# Altium schematic sheet Debug.SchDoc(Debug)
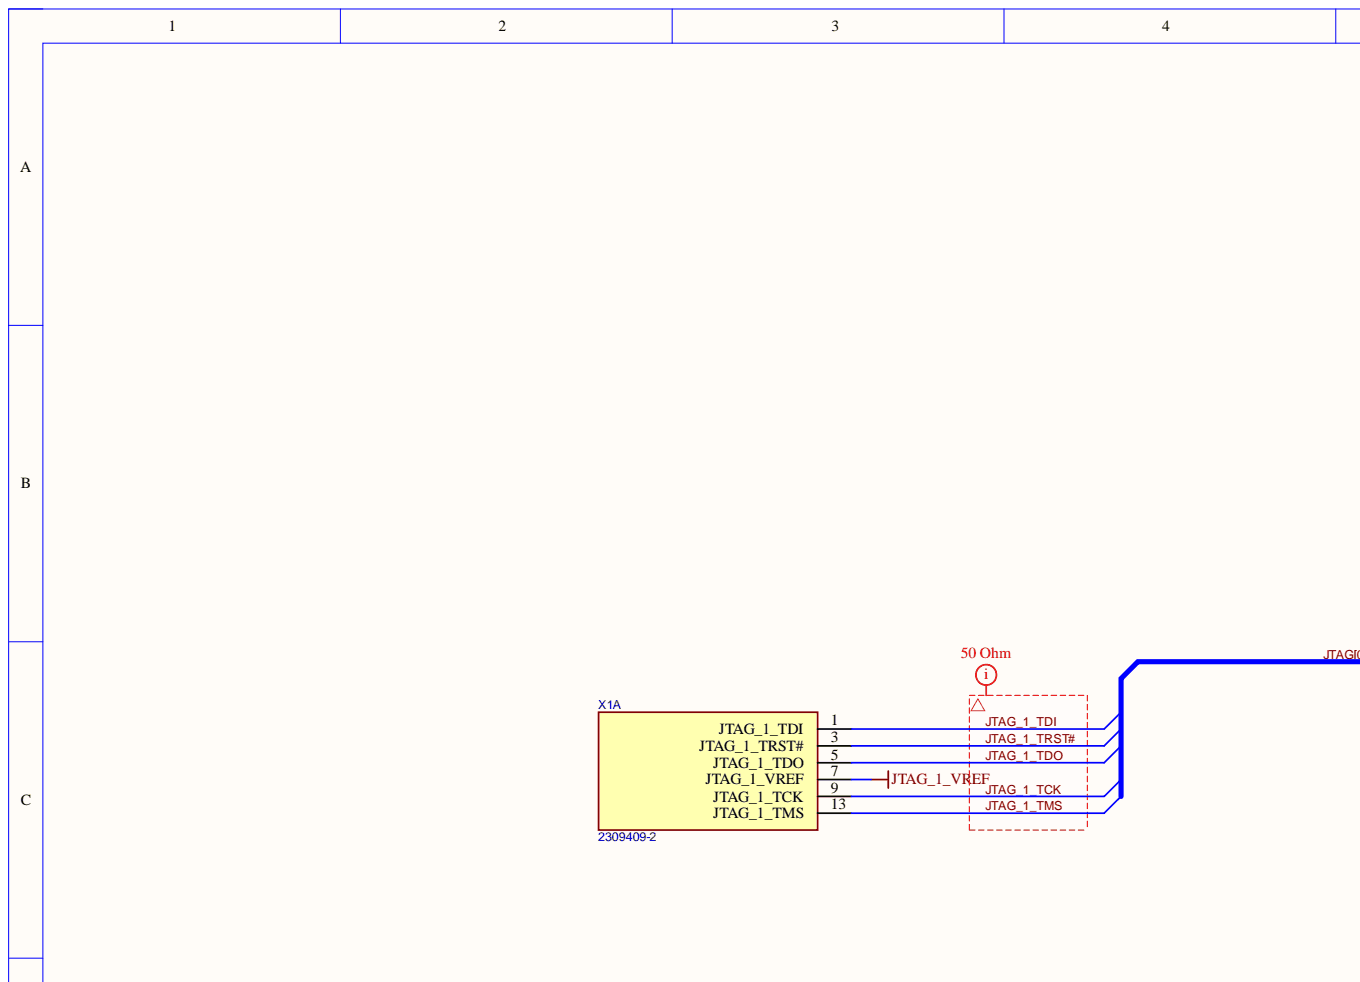
[diagram p0: Debug.SchDoc, top left]
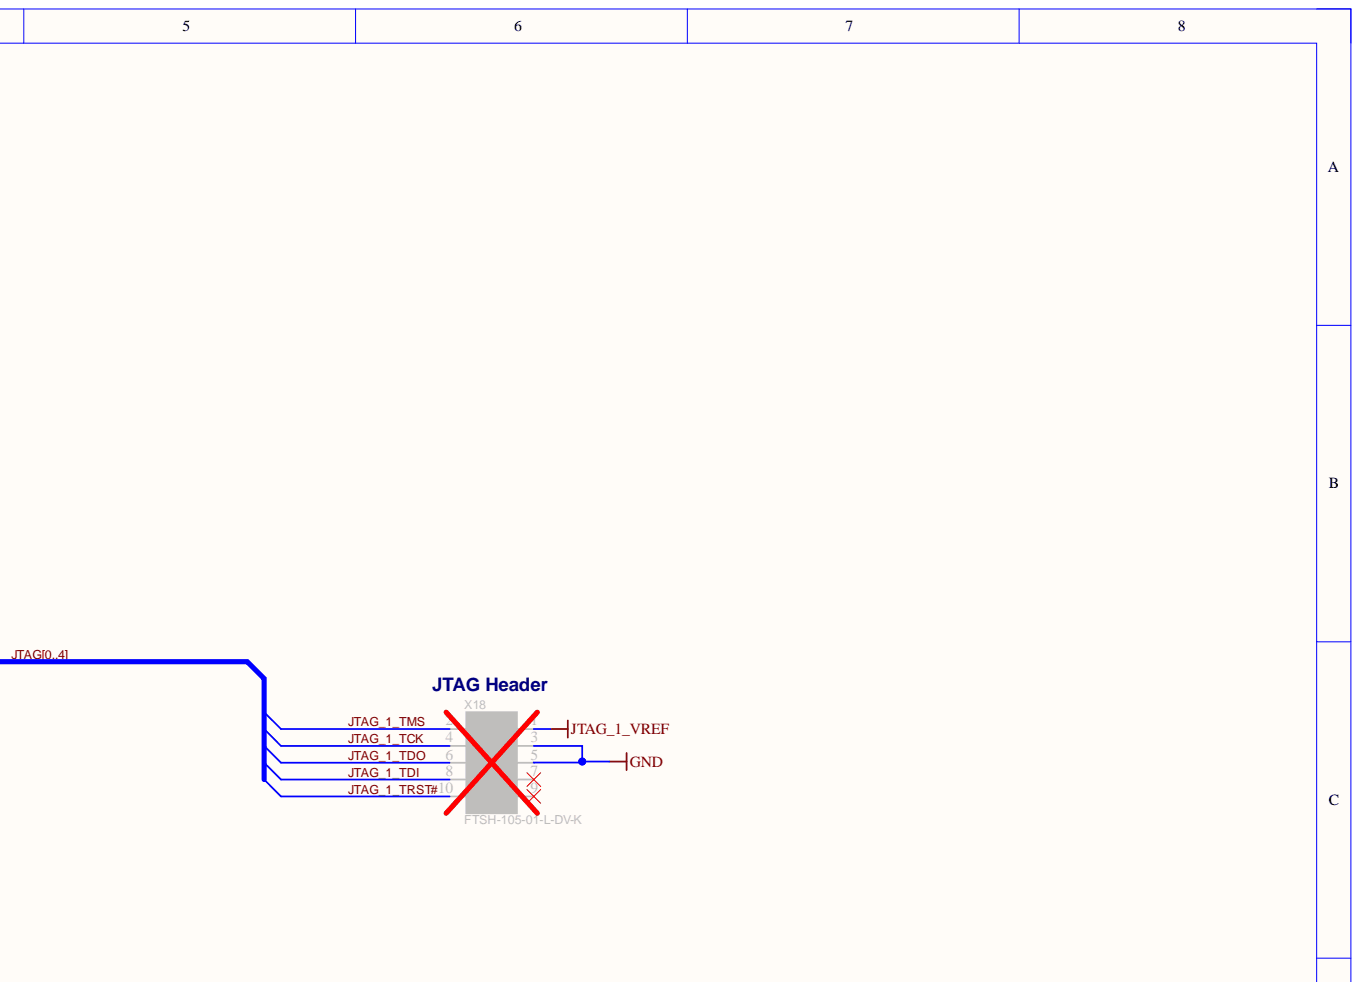
[diagram p1: Debug.SchDoc, top right]
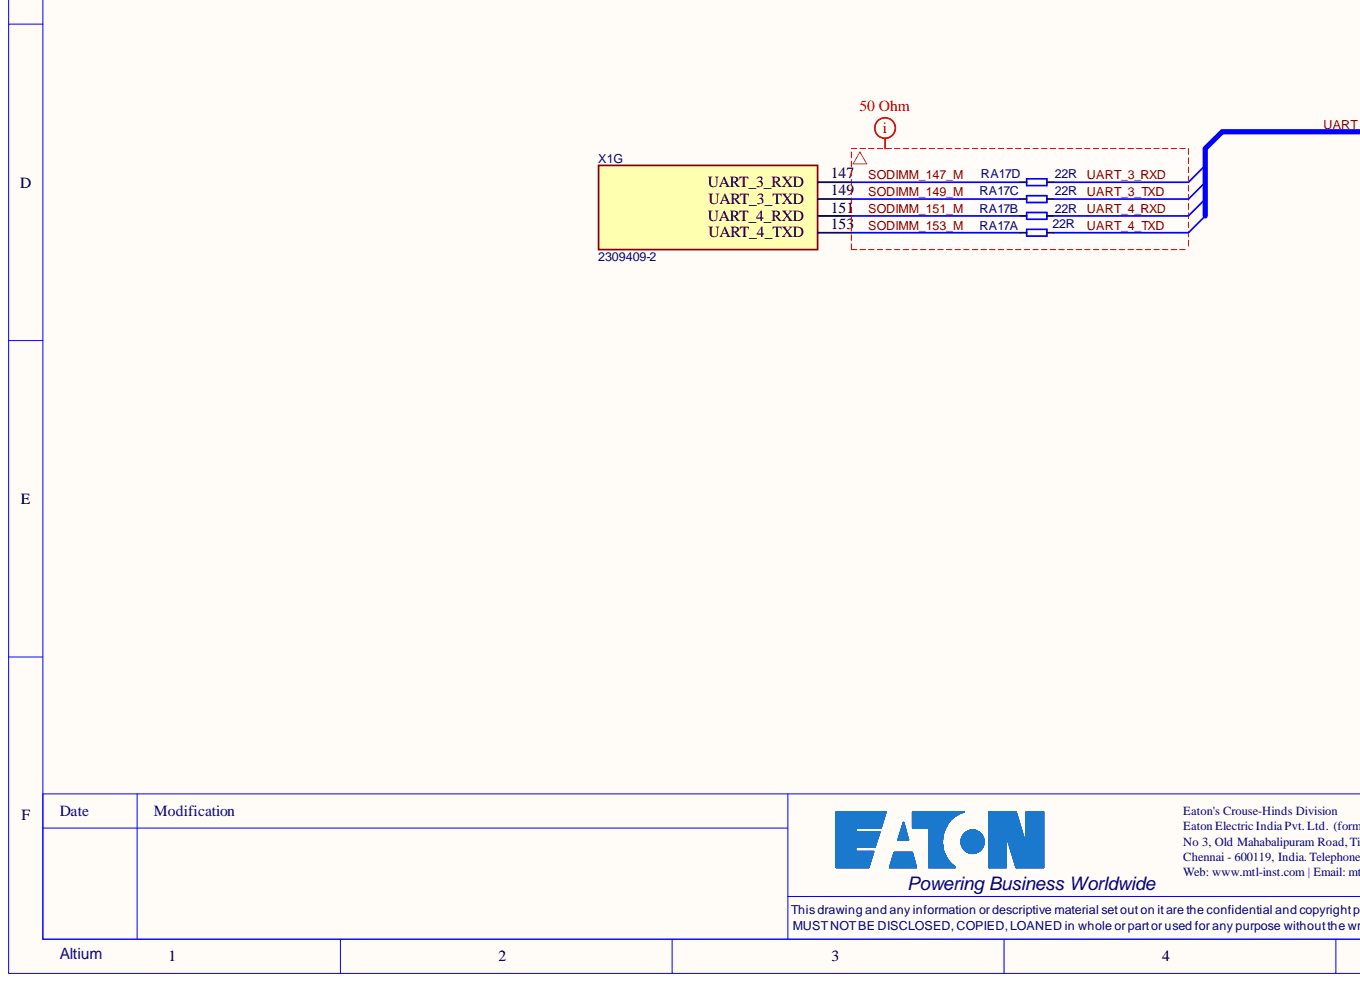
[diagram p2: Debug.SchDoc, bottom left]
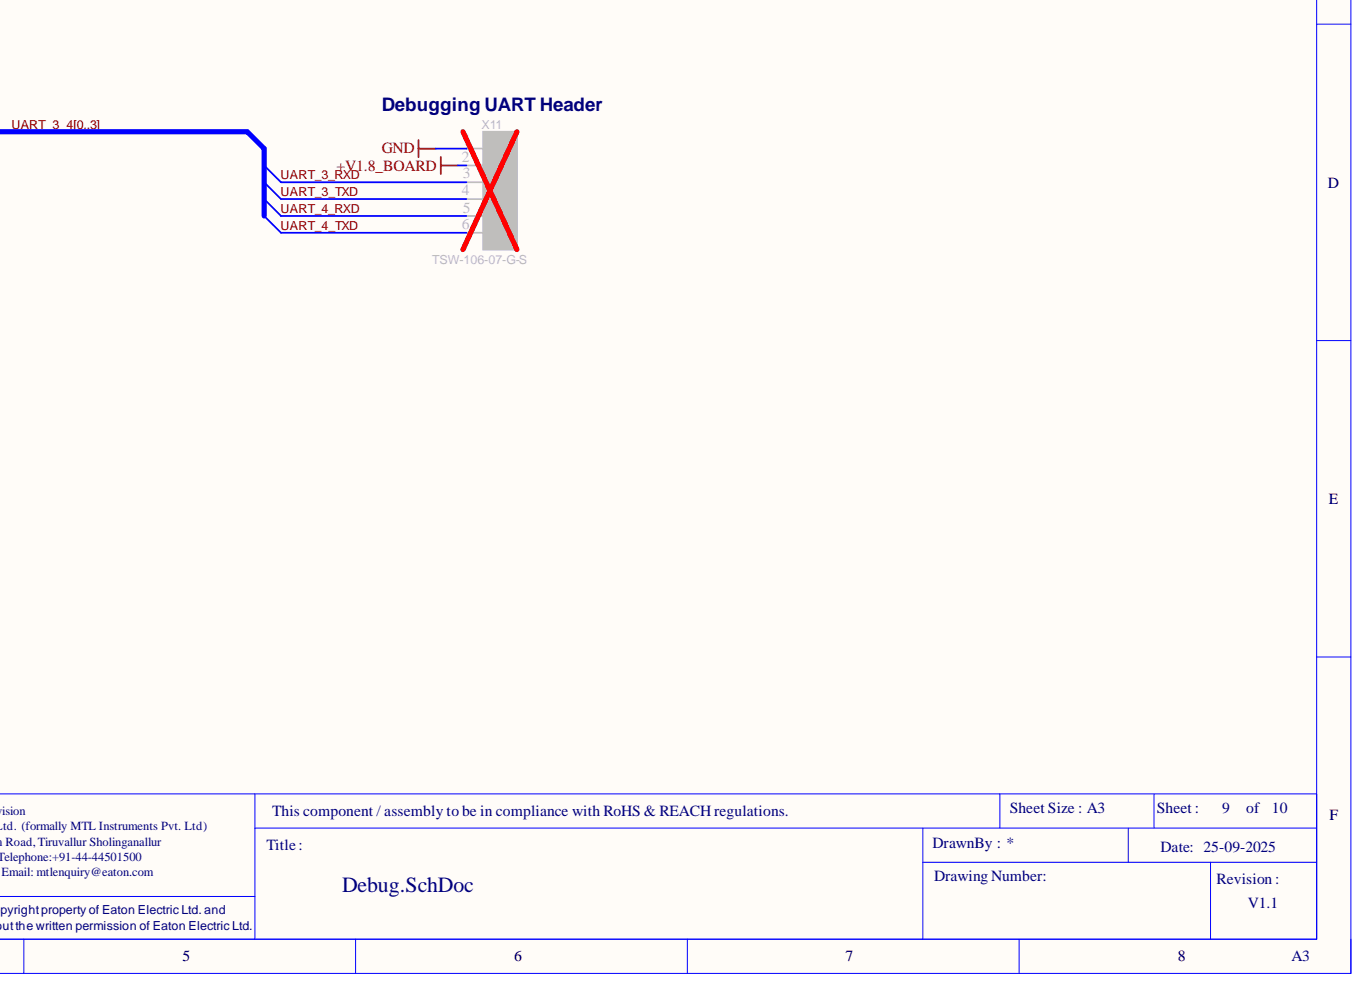
[diagram p3: Debug.SchDoc, bottom right]

## Parts
RA17  22R  [T_Resistor_Array_0402_X4]  (parts A,B,C,D)
X1  2309409-2  [CON-TE-2309409-2]  (parts A,G)
X11  TSW-106-07-G-S  [T_samtech_TSW-106-07-G-S]
X18  FTSH-105-01-L-DV-K  [T_Samtec_FTSH-105-01-L-DV]

## Nets
+V1.8_BOARD: X11.2
GND: X11.1 X18.3 X18.5
JTAG_1_VREF: X1.7(JTAG_1_VREF) X18.1
JTAG_1_TCK: X1.9(JTAG_1_TCK)
JTAG_1_TDI: X1.1(JTAG_1_TDI) X18.8
JTAG_1_TDO: X1.5(JTAG_1_TDO) X18.6
JTAG_1_TMS: X1.13(JTAG_1_TMS) X18.2
JTAG_1_TRST#: X1.3(JTAG_1_TRST#) X18.10
SODIMM_147_M: RA17.4 X1.147(UART_3_RXD)
SODIMM_149_M: RA17.3 X1.149(UART_3_TXD)
SODIMM_151_M: RA17.2 X1.151(UART_4_RXD)
SODIMM_153_M: RA17.1 X1.153(UART_4_TXD)
UART_3_RXD: RA17.8 X11.3
UART_3_TXD: RA17.7 X11.4
UART_4_RXD: RA17.6 X11.5
UART_4_TXD: RA17.5 X11.6
JTAG0:  [also labelled JTAG]
JTAG1:  [also labelled JTAG]
JTAG2:  [also labelled JTAG]
JTAG3:  [also labelled JTAG]
JTAG4:  [also labelled JTAG]
UART_3_40:  [also labelled UART_3_4]
UART_3_41:  [also labelled UART_3_4]
UART_3_42:  [also labelled UART_3_4]
UART_3_43:  [also labelled UART_3_4]

Not connected: X18 4 7 9

## Library data of the parts
RA17 = RA-22R-63mW-5%-0804-div: Resistor Array 22 R 63mW 5% 0804, Concave type; Component Kind: Standard; Library Name: SoM_Camera_Encoder.SCHLIB; Power Dissipation: 63mW; Tolerance: 5%; Value: 22R
X1 = CON-TE-2309409-2: DDR4 SODIMM Socket, 260 pins, 5.2mm(H), 0.5mm Pitch; Manufacturer Name: TE Connectivity; Component Kind: Standard; Manufacturer Part No.: 2309409-2; Package: DDR4-SODIMM-260; Temperature Range: -40C to +125C; Library Name: Mallow.SchLib
X11 = CON-Samtec-TSW-106-07-G-S: Header male, 1X6 Pins, 2.54 mm pitch; Manufacturer Name: Samtec; Pitch: 2.54 mm; Component Kind: Standard; Manufacturer Part No.: TSW-106-07-G-S; No. of ways: 1X6; Library Name: Mallow.SchLib
X18 = CON-Samtec-FTSH-105-01-L-DV-1X10-1.27mm: Male Header, 10-Pin, 1.27mm Pitch; Manufacturer Name: Samtec; No. of ways: 1X10; Component Kind: Standard; Manufacturer Part No.: FTSH-105-01-L-DV-K; Pitch: 1.27mm; Library Name: Mallow.SchLib
